# Altium schematic sheet JGL1905_Xyloni_FPGA_V1.06.SchDoc
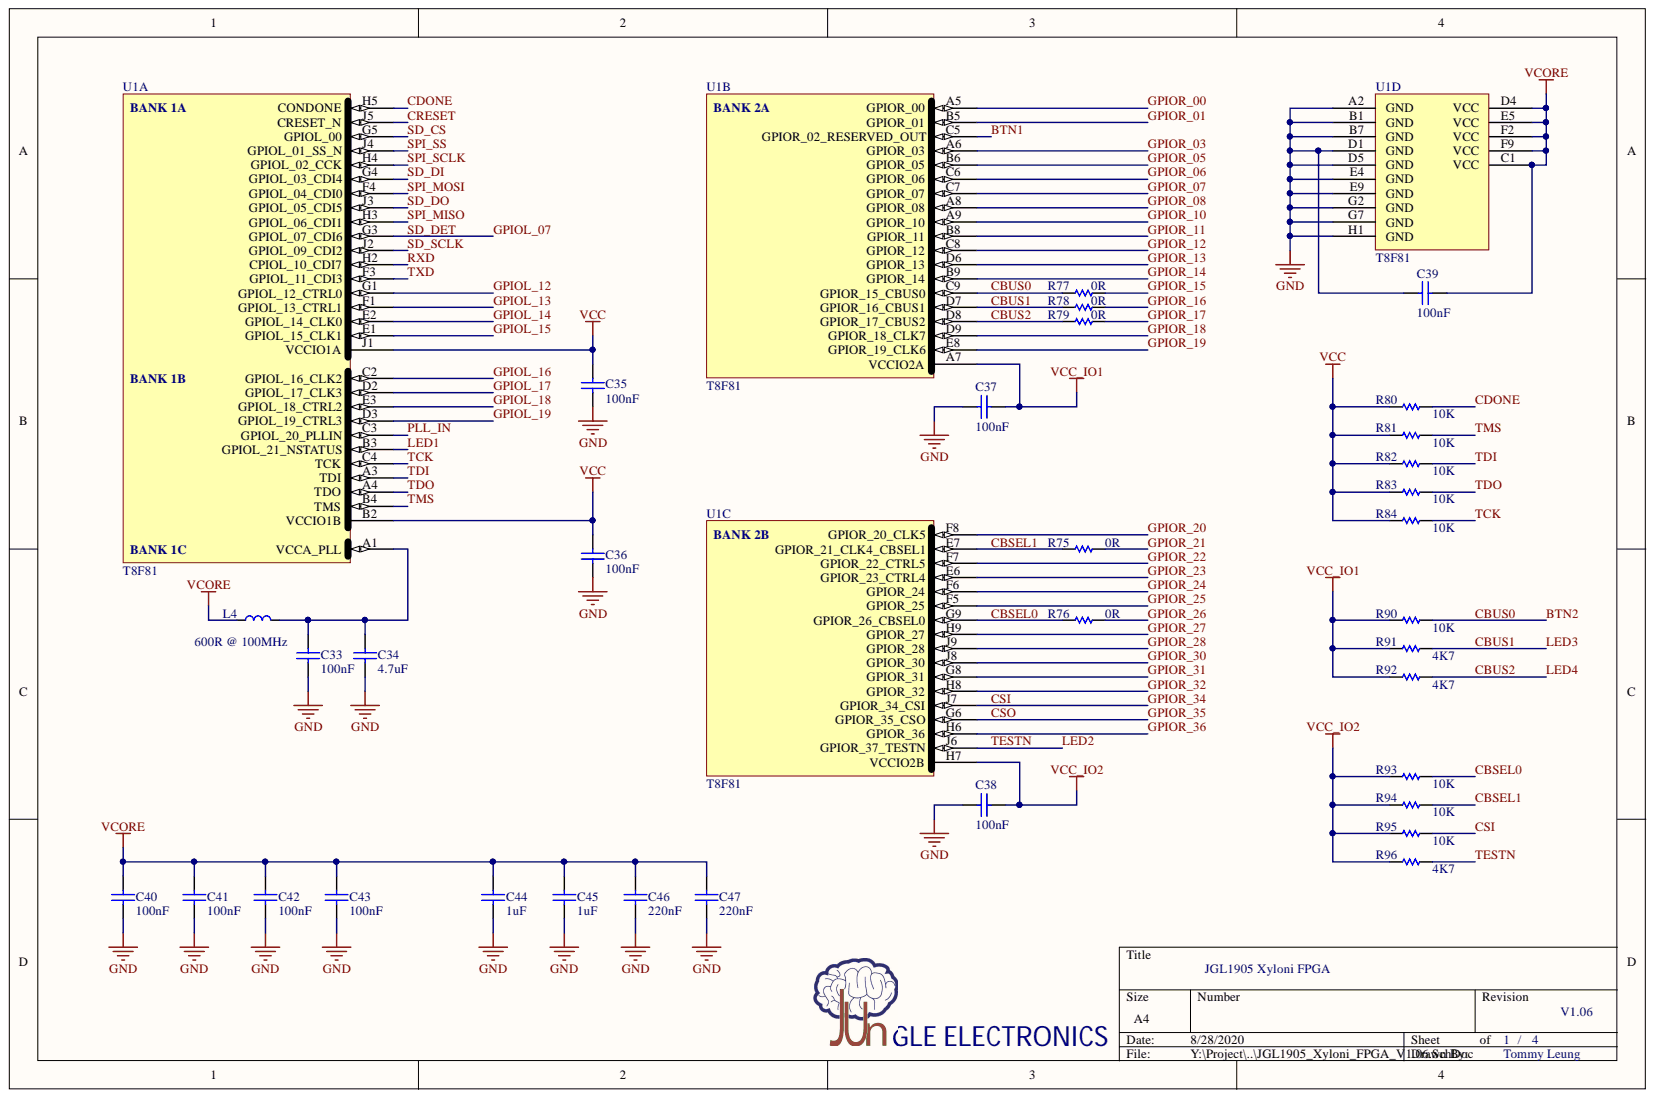
[diagram p0: JGL1905_Xyloni_FPGA_V1.06.SchDoc]

## Parts
C33  value 100nF  [CAPC0201M]  (hidden comment: CAPACITOR)
C34  value 4.7uF  [CAPC0402M]  (hidden comment: CAPACITOR)
C35  value 100nF  [CAPC0201M]  (hidden comment: CAPACITOR)
C36  value 100nF  [CAPC0201M]  (hidden comment: CAPACITOR)
C37  value 100nF  [CAPC0201M]  (hidden comment: CAPACITOR)
C38  value 100nF  [CAPC0201M]  (hidden comment: CAPACITOR)
C39  value 100nF  [CAPC0201M]  (hidden comment: CAPACITOR)
C40  value 100nF  [CAPC0201M]  (hidden comment: CAPACITOR)
C41  value 100nF  [CAPC0201M]  (hidden comment: CAPACITOR)
C42  value 100nF  [CAPC0201M]  (hidden comment: CAPACITOR)
C43  value 100nF  [CAPC0201M]  (hidden comment: CAPACITOR)
C44  value 1uF  [CAPC0201M]  (hidden comment: CAPACITOR)
C45  value 1uF  [CAPC0201M]  (hidden comment: CAPACITOR)
C46  value 220nF  [CAPC0201M]  (hidden comment: CAPACITOR)
C47  value 220nF  [CAPC0201M]  (hidden comment: CAPACITOR)
L4  value 600R @ 100MHz  [RESC0603M]  (hidden comment: FERRITE_BEADS)
R75  value 0R  [RESC0402M]  (hidden comment: RESISTOR)
R76  value 0R  [RESC0402M]  (hidden comment: RESISTOR)
R77  value 0R  [RESC0402M]  (hidden comment: RESISTOR)
R78  value 0R  [RESC0402M]  (hidden comment: RESISTOR)
R79  value 0R  [RESC0402M]  (hidden comment: RESISTOR)
R80  value 10K  [RESC0402M]  (hidden comment: RESISTOR)
R81  value 10K  [RESC0402M]  (hidden comment: RESISTOR)
R82  value 10K  [RESC0402M]  (hidden comment: RESISTOR)
R83  value 10K  [RESC0402M]  (hidden comment: RESISTOR)
R84  value 10K  [RESC0402M]  (hidden comment: RESISTOR)
R90  value 10K  [RESC0402M]  (hidden comment: RESISTOR)
R91  value 4K7  [RESC0402M]  (hidden comment: RESISTOR)
R92  value 4K7  [RESC0402M]  (hidden comment: RESISTOR)
R93  value 10K  [RESC0402M]  (hidden comment: RESISTOR)
R94  value 10K  [RESC0402M]  (hidden comment: RESISTOR)
R95  value 10K  [RESC0402M]  (hidden comment: RESISTOR)
R96  value 4K7  [RESC0402M]  (hidden comment: RESISTOR)
U1  T8F81  [BGA-81]  (parts A,B,C,D)

## Nets
GND: C33.1 C34.1 C35.1 C36.1 C37.1 C38.2 C39.2 C40.1 C41.1 C42.1 C43.1 C44.1 C45.1 C46.1 C47.1 U1.A2(GND) U1.B1(GND) U1.B7(GND) U1.D1(GND) U1.D5(GND) U1.E4(GND) U1.E9(GND) U1.G2(GND) U1.G7(GND) U1.H1(GND)
VCC: C35.2 C36.2 R80.2 R81.2 R82.2 R83.2 R84.2 U1.B2(VCCIO1B) U1.J1(VCCIO1A)
VCC_IO1: C37.2 R90.2 R91.2 R92.2 U1.A7(VCCIO2A)
VCC_IO2: C38.1 R93.2 R94.2 R95.2 R96.2 U1.H7(VCCIO2B)
VCORE: C39.1 C40.2 C41.2 C42.2 C43.2 C44.2 C45.2 C46.2 C47.2 L4.1 U1.C1(VCC) U1.D4(VCC) U1.E5(VCC) U1.F2(VCC) U1.F9(VCC)
BTN1: U1.C5(GPIOR_02_RESERVED_OUT)
BTN2: R77.2 R90.1 U1.C9(GPIOR_15_CBUS0)
CBSEL0: R76.2 R93.1 U1.G9(GPIOR_26_CBSEL0)
CBSEL1: R75.2 R94.1 U1.E7(GPIOR_21_CLK4_CBSEL1)
CBUS1: R78.2 R91.1 U1.D7(GPIOR_16_CBUS1)
CBUS2: R79.2 R92.1 U1.D8(GPIOR_17_CBUS2)
CDONE: R80.1 U1.H5(CONDONE)
CRESET: U1.J5(CRESET_N)
CSI: R95.1 U1.J7(GPIOR_34_CSI)
CSO: U1.G6(GPIOR_35_CSO)
GPIOL_07: U1.G3(GPIOL_07_CDI6)
GPIOL_12: U1.G1(GPIOL_12_CTRL0)
GPIOL_13: U1.F1(GPIOL_13_CTRL1)
GPIOL_14: U1.E2(GPIOL_14_CLK0)
GPIOL_15: U1.E1(GPIOL_15_CLK1)
GPIOL_16: U1.C2(GPIOL_16_CLK2)
GPIOL_17: U1.D2(GPIOL_17_CLK3)
GPIOL_18: U1.E3(GPIOL_18_CTRL2)
GPIOL_19: U1.D3(GPIOL_19_CTRL3)
GPIOR_00: U1.A5(GPIOR_00)
GPIOR_01: U1.B5(GPIOR_01)
GPIOR_03: U1.A6(GPIOR_03)
GPIOR_05: U1.B6(GPIOR_05)
GPIOR_06: U1.C6(GPIOR_06)
GPIOR_07: U1.C7(GPIOR_07)
GPIOR_08: U1.A8(GPIOR_08)
GPIOR_10: U1.A9(GPIOR_10)
GPIOR_11: U1.B8(GPIOR_11)
GPIOR_12: U1.C8(GPIOR_12)
GPIOR_13: U1.D6(GPIOR_13)
GPIOR_14: U1.B9(GPIOR_14)
GPIOR_15: R77.1
GPIOR_16: R78.1
GPIOR_17: R79.1
GPIOR_18: U1.D9(GPIOR_18_CLK7)
GPIOR_19: U1.E8(GPIOR_19_CLK6)
GPIOR_20: U1.F8(GPIOR_20_CLK5)
GPIOR_21: R75.1
GPIOR_22: U1.F7(GPIOR_22_CTRL5)
GPIOR_23: U1.E6(GPIOR_23_CTRL4)
GPIOR_24: U1.F6(GPIOR_24)
GPIOR_25: U1.F5(GPIOR_25)
GPIOR_26: R76.1
GPIOR_27: U1.H9(GPIOR_27)
GPIOR_28: U1.J9(GPIOR_28)
GPIOR_30: U1.J8(GPIOR_30)
GPIOR_31: U1.G8(GPIOR_31)
GPIOR_32: U1.H8(GPIOR_32)
GPIOR_36: U1.H6(GPIOR_36)
LED1: U1.B3(GPIOL_21_NSTATUS)
LED2: R96.1 U1.J6(GPIOR_37_TESTN)
PLL_IN: U1.C3(GPIOL_20_PLLIN)
RXD: U1.H2(CPIOL_10_CDI7)
SD_CS: U1.G5(GPIOL_00)
SD_DI: U1.G4(GPIOL_03_CDI4)
SD_DO: U1.J3(GPIOL_05_CDI5)
SD_SCLK: U1.J2(GPIOL_09_CDI2)
SPI_MISO: U1.H3(GPIOL_06_CDI1)
SPI_MOSI: U1.F4(GPIOL_04_CDI0)
SPI_SCLK: U1.H4(GPIOL_02_CCK)
SPI_SS: U1.J4(GPIOL_01_SS_N)
TCK: R84.1 U1.C4(TCK)
TDI: R82.1 U1.A3(TDI)
TDO: R83.1 U1.A4(TDO)
TMS: R81.1 U1.B4(TMS)
TXD: U1.F3(GPIOL_11_CDI3)
NetC33_2: C33.2 C34.2 L4.2 U1.A1(VCCA_PLL)

## Library data of the parts
C33 C35-C43 = CAPACITOR: Mfr. PN: GRM033C71A104KE14D; Component Kind: Standard; Description: Capacitor; Supplier 1: Mouser; Supplier Part Number 1: 81-GRM033C71A104KE4D; Library Name: Jungle_ADLibrary.IntLib
C34 = CAPACITOR: Mfr. PN: GRM155R60J475ME7J; Component Kind: Standard; Description: Capacitor; Supplier 1: Mouser; Supplier Part Number 1: 81-GRM155R60J475ME7J; Library Name: Jungle_ADLibrary.IntLib
C44 C45 = CAPACITOR: Mfr. PN: CC0201MRX5R4BB105; Component Kind: Standard; Description: Capacitor; Supplier 1: Mouser; Supplier Part Number 1: 603-CC0201MRX54BB105; Library Name: Jungle_ADLibrary.IntLib
C46 C47 = CAPACITOR: Mfr. PN: GRM033R61E224KE01D; Component Kind: Standard; Description: Capacitor; Supplier 1: Mouser; Supplier Part Number 1: 81-GRM033R61E224KE1D; Library Name: Jungle_ADLibrary.IntLib
L4 = FERRITE_BEADS: Ferrite Beads; Mfr. PN: BK1608HS601-T; Value: 600R @ 100MHz; Component Kind: Standard; Supplier 1: Mouser; Supplier Part Number 1: 963-BK1608HS601-T; Library Name: Jungle_ADLibrary.IntLib
R75-R79 = RESISTOR: Mfr. PN: RC0402FR-070RL; Component Kind: Standard; Description: Resistor; Supplier 1: Mouser; Supplier Part Number 1: 603-RC0402FR-070RL; Library Name: Jungle_ADLibrary.IntLib
R80-R84 R90 R93-R95 = RESISTOR: Mfr. PN: RC0402FR-0710KL; Component Kind: Standard; Description: Resistor; Supplier 1: Mouser; Supplier Part Number 1: 603-RC0402FR-0710KL; Library Name: Jungle_ADLibrary.IntLib
R91 R92 R96 = RESISTOR: Mfr. PN: RC0402FR-074K7L; Component Kind: Standard; Description: Resistor; Supplier 1: Mouser; Supplier Part Number 1: 603-RC0402FR-074K7L; Library Name: Jungle_ADLibrary.IntLib
U1 = T8F81: Trion T8 FPGA; Mfr. PN: T8F81C2; Component Kind: Standard; Supplier 1: Effinix; Library Name: Jungle_ADLibrary.IntLib
